# Altium schematic sheet Power.SchDoc
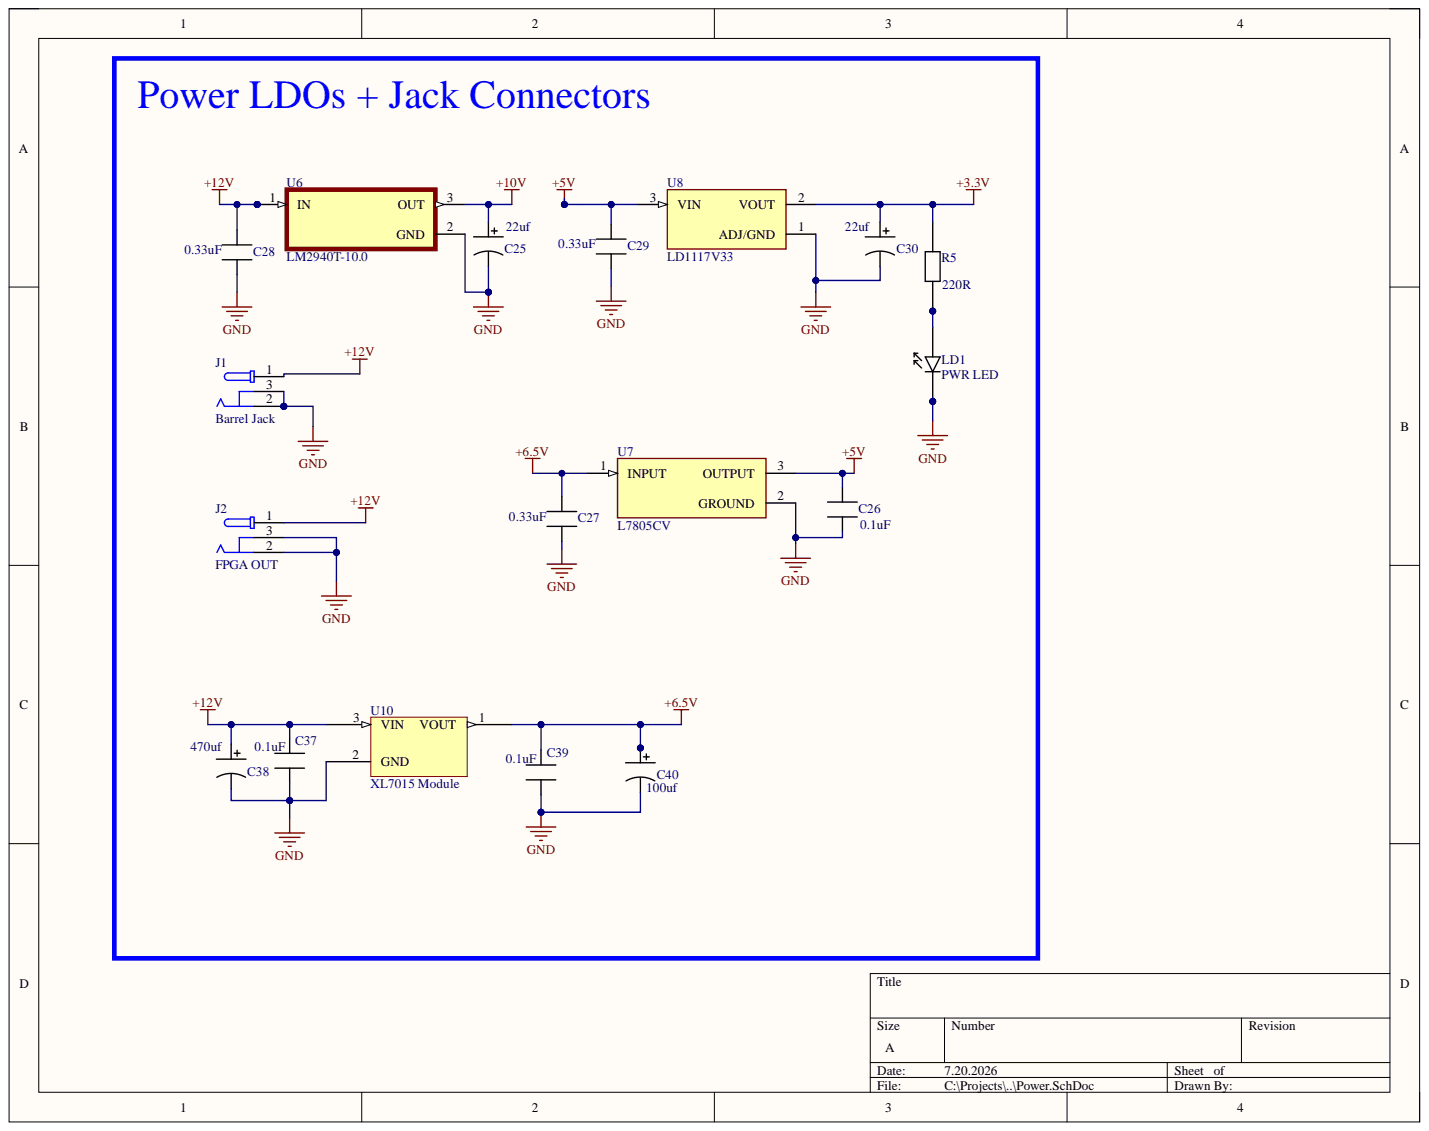
[diagram p0: Power.SchDoc]

## Parts
C25  22uf  [CAP_CAN_D5MM]
C26  0.1uF  [CAP_0805]
C27  0.33uF  [CAP_0805]
C28  0.33uF  [CAP_0805]
C29  0.33uF  [CAP_0805]
C30  22uf  [CAP_CAN_D5MM]
C37  0.1uF  [CAP_0805]
C38  470uf  [CAP_CAN_D10mm]
C39  0.1uF  [CAP_0805]
C40  100uf  [CAP_CAN_D5MM]
J1  Barrel Jack  [MPD_EJ503A]
J2  FPGA OUT  [MPD_EJ503A]
LD1  PWR LED  [LED_0805]
R5  220R  [RES_0805]
U6  LM2940T-10.0  [TO254P1054X470X1955-3]
U7  L7805CV  [TO255P1040X460X1968-3]
U8  LD1117V33  [TO255P1020X450X1968-3]
U10  XL7015 Module  [XL7015]

## Nets
+3.3V: C30.1 R5.2 U8.2
+5V: C26.2 C29.2 U7.3 U8.3
+6.5V: C27.2 C39.2 C40.1 U7.1 U10.1
+10V: C25.1 U6.3
+12V: C28.2 C37.2 C38.1 J1.1 J2.1 U6.1 U10.3
GND: C25.2 C26.1 C27.1 C28.1 C29.1 C30.2 C37.1 C38.2 C39.1 C40.2 J1.2 J1.3 J2.2 J2.3 LD1.1 U6.2 U7.2 U8.1 U10.2
NetLD1_2: LD1.2 R5.1

## Library data of the parts
C25 C30 C40 = CAP_CAN_D5mm: SMD Can Capacitor; 5mm Diameter; note: any manufacturer; Component Kind: Standard; Library Name: Passives.SchLib; sample link: https://www.lcsc.com/product-detail/C3357.html?s_z=n_10uF%2520SMD%2520Can%2520Capacitor%2520D5%2520RVT
  C25 C30: val: 22uf
  C40: val: 100uf
C26-C29 C37 C39 = CAP_0805: note: any manufacturer; Component Kind: Standard; Description: CAP_0805; Library Name: Passives.SchLib
  C26 C37 C39: val: 0.1uF
  C27-C29: val: 0.33uF
C38 = CAP_CAN_D10mm: SMD Can Capacitor; 10mm Diameter; note: Original; Component Kind: Standard; Library Name: Passives.SchLib; sample link: https://www.lcsc.com/product-detail/Aluminum-Electrolytic-span-style-background-color-ff0-Capacitors-span-SMD_ROQANG-RVT2A470M1010_C335988.html; val: 470uf
J1 J2 = EJ503A: Power Barrel Connector Jack 2.10mm ID (0.083), 5.50mm OD (0.217) Through Hole, Right Angle; MF: MPD; Availability: In Stock; Check_prices: https://www.snapeda.com/parts/EJ503A/MPD+%2528Memory+Protection+Devices%2529/view-part/?ref=eda; Component Kind: Standard; Library Name: Connectors.SchLib; MP: EJ503A; Package: None; Price: None; Purchase-URL: https://pricing.snapeda.com/search?q=EJ503A&ref=eda; SnapEDA_Link: https://www.snapeda.com/parts/EJ503A/MPD+%2528Memory+Protection+Devices%2529/view-part/?ref=snap
LD1 = LED_0805: Component Kind: Standard; Description: LED_0805; Library Name: Passives.SchLib
R5 = RES_0805: note: any manufacturer; Component Kind: Standard; Description: RES_0805; Library Name: Passives.SchLib; val: 220R
U6 = LM2940T-10.0: 1-A, 26-V, high-PSRR, low-dropout voltage regulator 3-TO-220 -40 to 125; MF: Texas Instruments; MP: LM2940T-5.0; Check_prices: https://www.snapeda.com/parts/LM2940T-5.0/Texas+Instruments/view-part/?ref=eda; Component Kind: Standard; Library Name: IC_Power.SchLib; Package: TO-220-3 Texas Instruments; SnapEDA_Link: https://www.snapeda.com/parts/LM2940T-5.0/Texas+Instruments/view-part/?ref=snap
U7 = L7805CV: Linear Voltage Regulator IC Positive Fixed 1 Output 1.5A TO-220; MF: STMicroelectronics; Check_prices: https://www.snapeda.com/parts/L7805CV/STMicroelectronics/view-part/?ref=eda; Component Kind: Standard; Library Name: IC_Power.SchLib; MP: L7805CV; Package: TO-220-3 STMicroelectronics; SnapEDA_Link: https://www.snapeda.com/parts/L7805CV/STMicroelectronics/view-part/?ref=snap
U8 = LD1117V33: Linear Voltage Regulator IC Positive Fixed 1 Output 800mA TO-220AB; MF: STMicroelectronics; Check_prices: https://www.snapeda.com/parts/LD1117V33/STMicroelectronics/view-part/?ref=eda; Component Kind: Standard; Library Name: IC_Power.SchLib; MP: LD1117V33; Package: TO-220-3 STMicroelectronics; SnapEDA_Link: https://www.snapeda.com/parts/LD1117V33/STMicroelectronics/view-part/?ref=snap
U10 = XL7015: Buck Converter; Component Kind: Standard; Library Name: IC_Power.SchLib
